# Altium schematic sheet IotMB_RevB.SchDoc
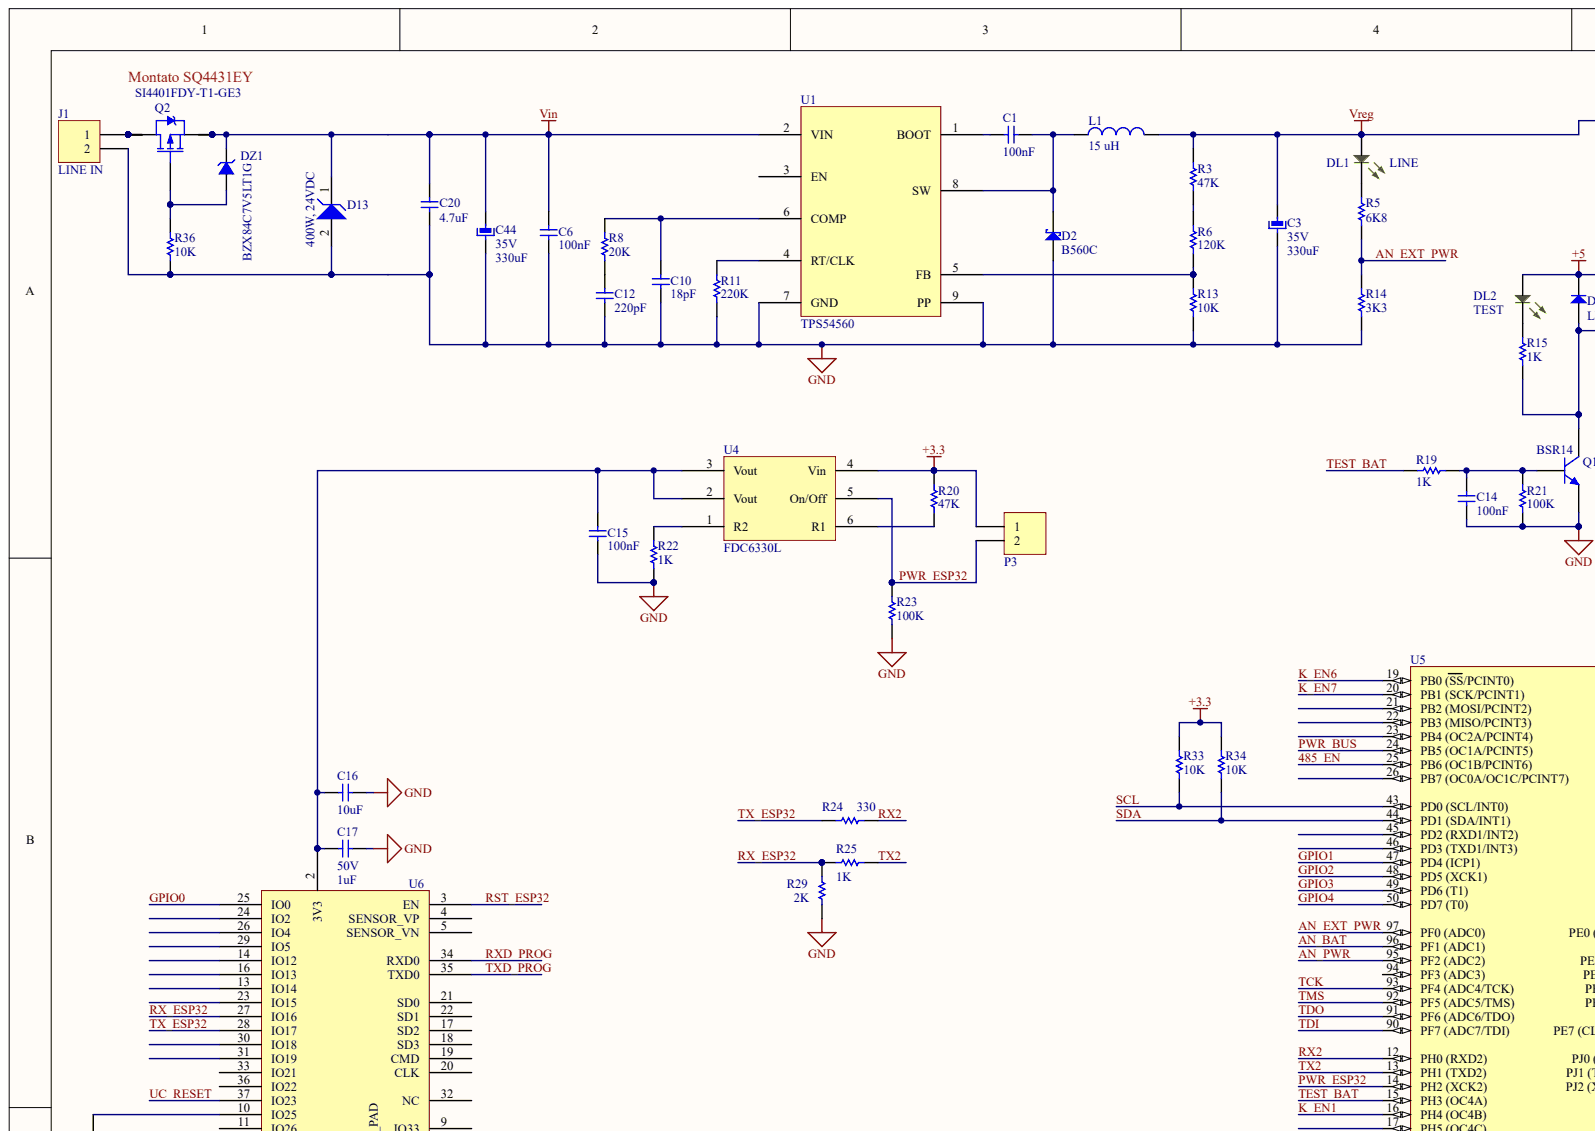
[diagram p0: IotMB_RevB.SchDoc, top left]
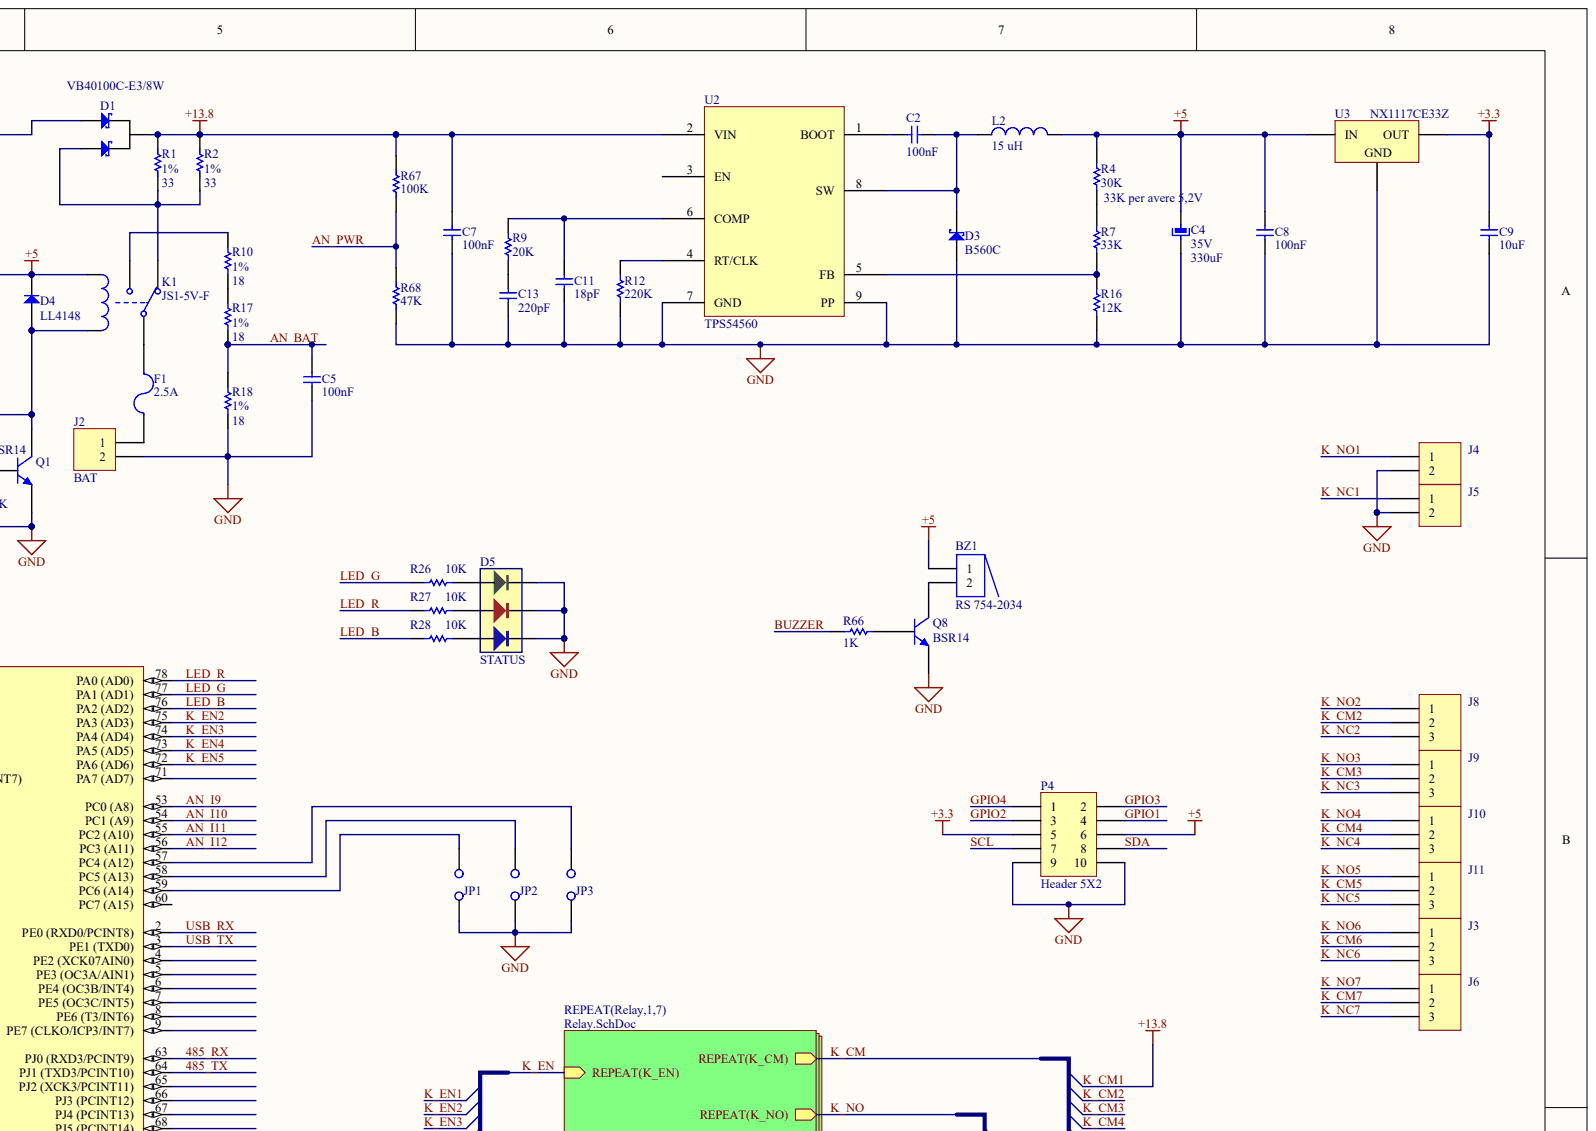
[diagram p1: IotMB_RevB.SchDoc, top right]
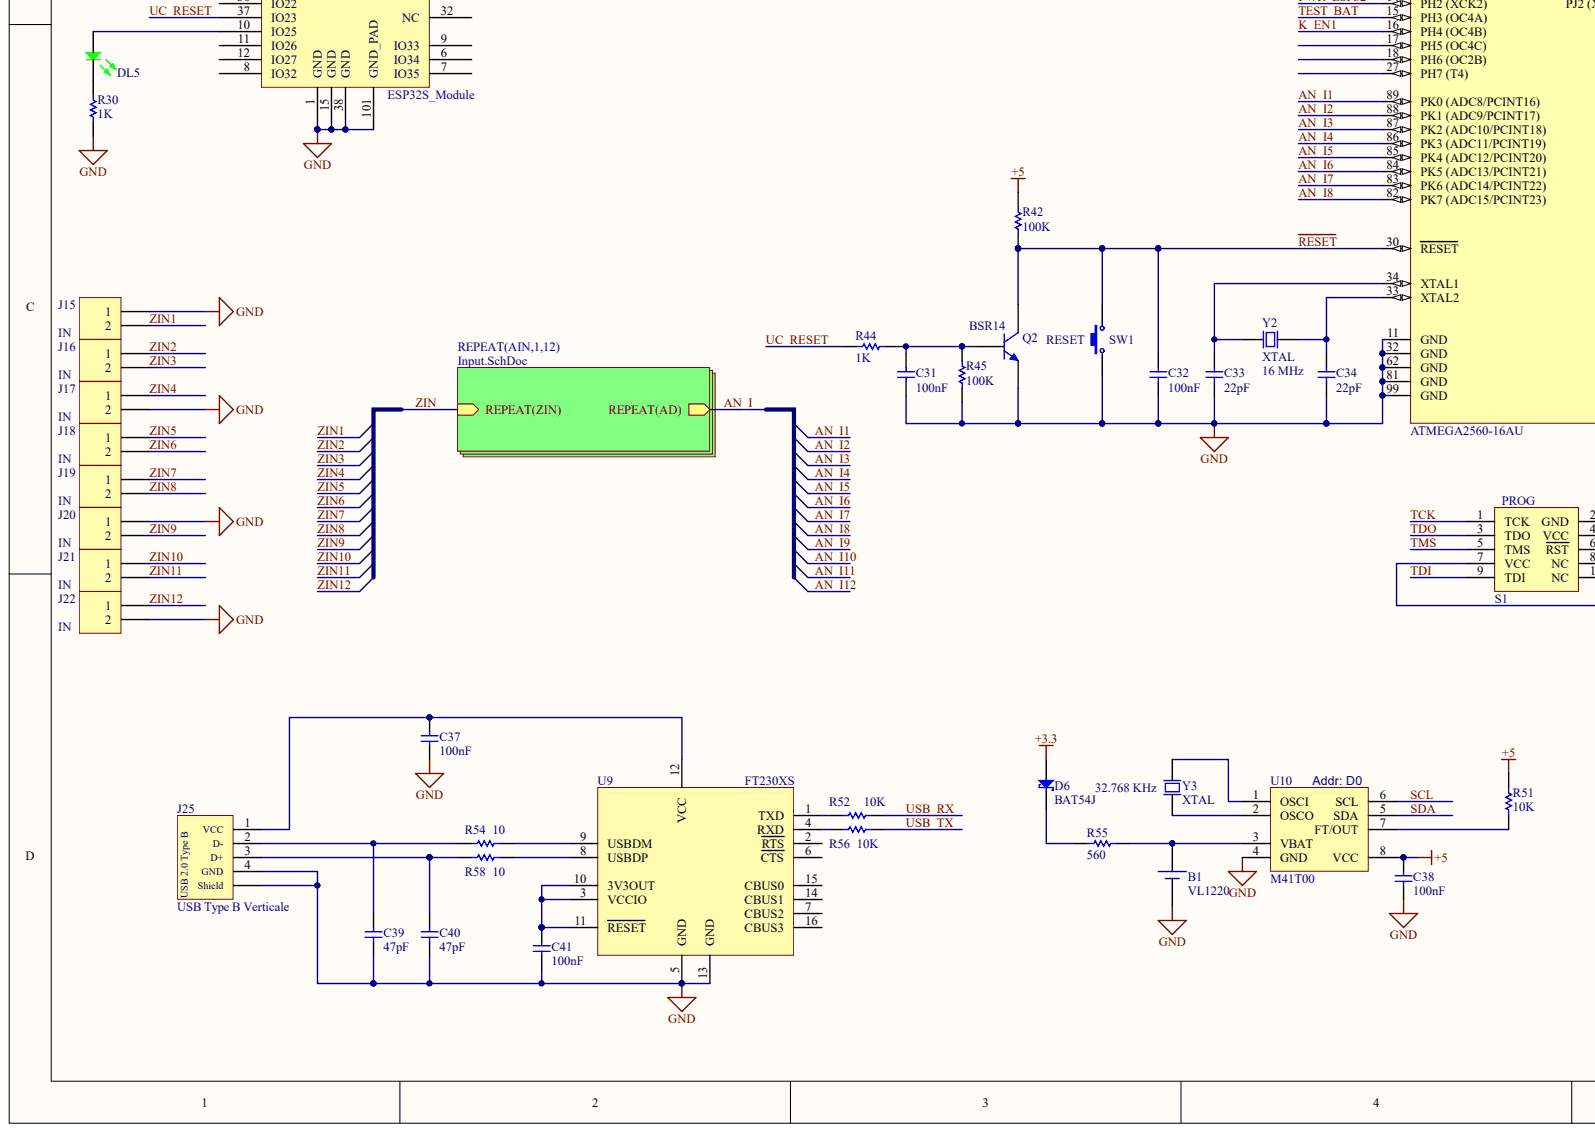
[diagram p2: IotMB_RevB.SchDoc, bottom left]
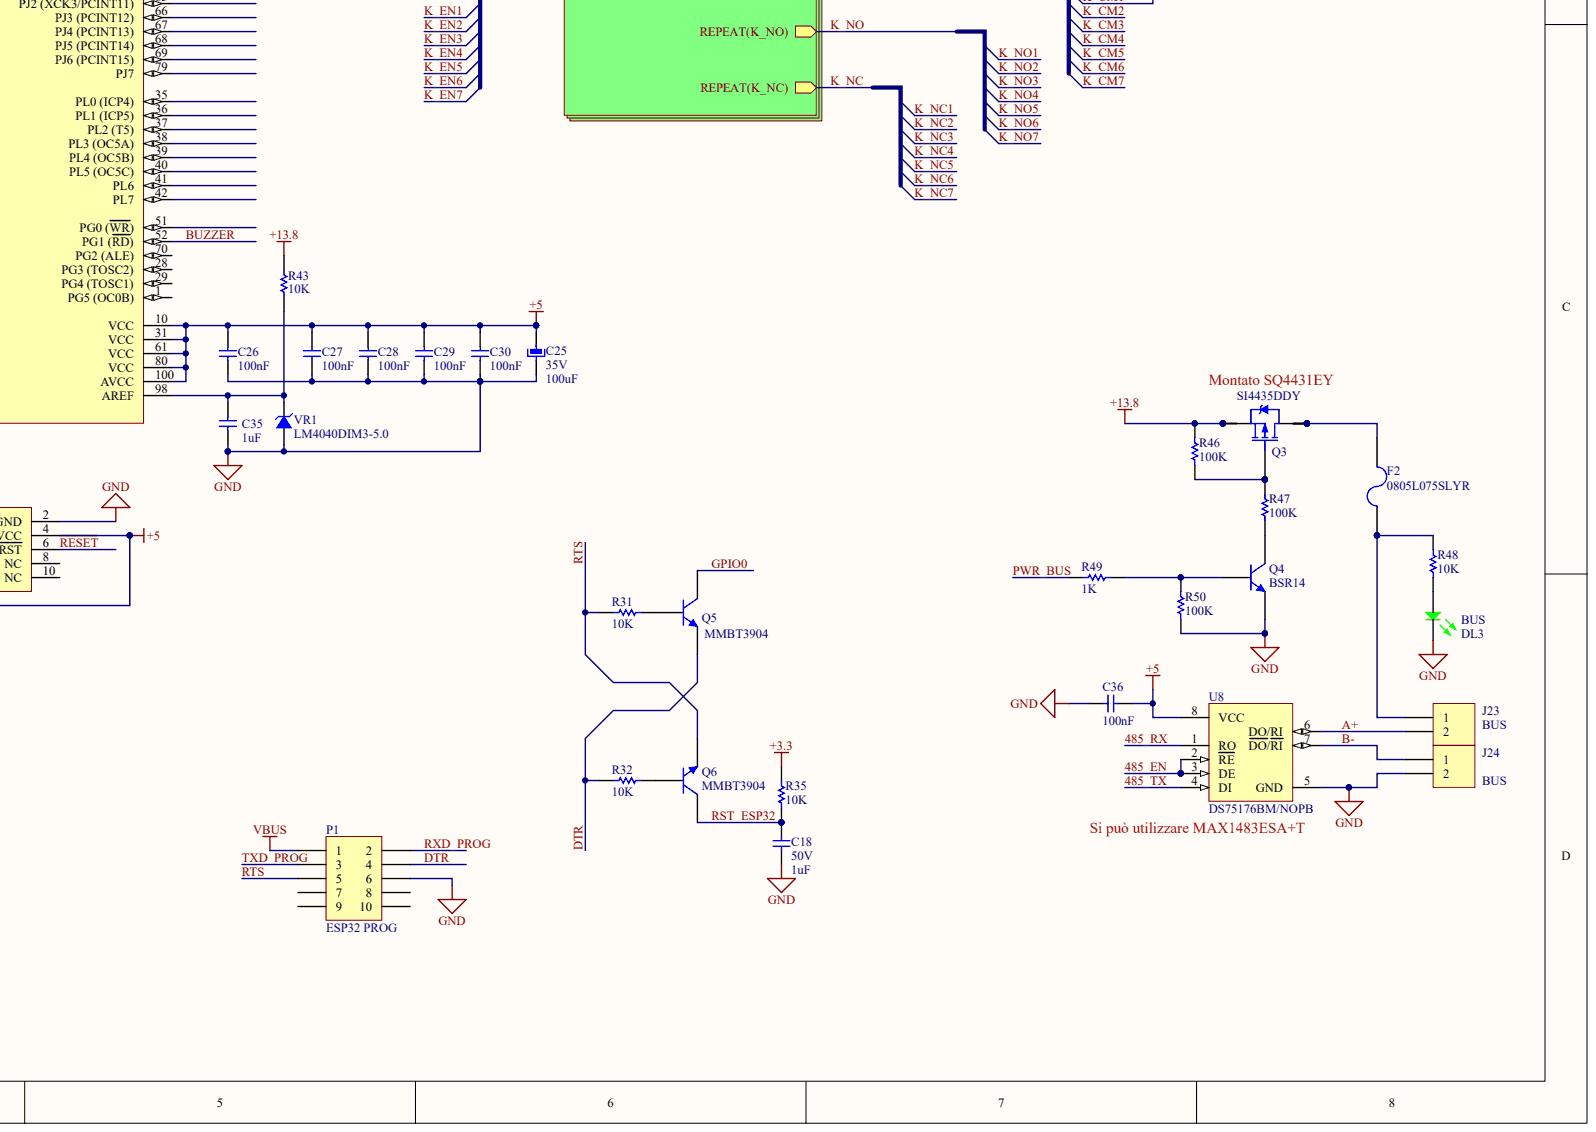
[diagram p3: IotMB_RevB.SchDoc, bottom right]

## Parts
B1  VL1220
BZ1  RS 754-2034
C1  value 100nF  (hidden comment: 50V)
C2  value 100nF  (hidden comment: 50V)
C3  35V  value 330uF
C4  35V  value 330uF
C5  value 100nF  (hidden comment: 50V)
C6  value 100nF  (hidden comment: 50V)
C7  value 100nF  (hidden comment: 50V)
C8  value 100nF  (hidden comment: 50V)
C9  value 10uF  (hidden comment: 25V)
C10  value 18pF  (hidden comment: 50V)
C11  value 18pF  (hidden comment: 50V)
C12  value 220pF  (hidden comment: 50V)
C13  value 220pF  (hidden comment: 50V)
C14  value 100nF  (hidden comment: 50V)
C15  value 100nF  (hidden comment: 50V)
C16  value 10uF  (hidden comment: 25V)
C17  50V  value 1uF
C18  50V  value 1uF
C20  value 4.7uF  (hidden comment: 50V)
C25  35V  value 100uF
C26  value 100nF  (hidden comment: 50V)
C27  value 100nF  (hidden comment: 50V)
C28  value 100nF  (hidden comment: 50V)
C29  value 100nF  (hidden comment: 50V)
C30  value 100nF  (hidden comment: 50V)
C31  value 100nF  (hidden comment: 50V)
C32  value 100nF  (hidden comment: 50V)
C33  value 22pF  (hidden comment: 100V)
C34  value 22pF  (hidden comment: 100V)
C35  value 1uF  (hidden comment: 50V)
C36  value 100nF  (hidden comment: 50V)
C37  value 100nF  (hidden comment: 50V)
C38  value 100nF  (hidden comment: 50V)
C39  value 47pF  (hidden comment: 50V)
C40  value 47pF  (hidden comment: 50V)
C41  value 100nF  (hidden comment: 50V)
C44  35V  value 330uF
D1  VB40100C-E3/8W
D2  B560C
D3  B560C
D4  LL4148
D5  STATUS
D6  BAT54J
D13  400W, 24VDC
DL1  LINE
DL2  TEST
DL3  BUS
DL5  Led verde 0805  (value not drawn)
DZ1  BZX84C7V5LT1G
F1  2.5A
F2  0805L075SLYR
J1  LINE IN
J2  BAT
J3  Morsetto 3 Poli a Vite  (value not drawn)
J4  Morsetto 2 Poli a Vite  (value not drawn)
J5  Morsetto 2 Poli a Vite  (value not drawn)
J6  Morsetto 3 Poli a Vite  (value not drawn)
J8  Morsetto 3 Poli a Vite  (value not drawn)
J9  Morsetto 3 Poli a Vite  (value not drawn)
J10  Morsetto 3 Poli a Vite  (value not drawn)
J11  Morsetto 3 Poli a Vite  (value not drawn)
J15  IN
J16  IN
J17  IN
J18  IN
J19  IN
J20  IN
J21  IN
J22  IN
J23  BUS
J24  BUS
J25  USB Type B Verticale  Interface Type: Type B  Sub-Family: USB 2.0
JP1  Jumper  (value not drawn)
JP2  Jumper  (value not drawn)
JP3  Jumper  (value not drawn)
K1  JS1-5V-F
L1  value 15 uH  (hidden comment: 8A)
L2  value 15 uH  (hidden comment: 8A)
P1  ESP32 PROG
P3  Header 2  (value not drawn)
P4  Header 5X2
Q1  BSR14
Q2  SI4401FDY-T1-GE3
Q2  BSR14
Q3  SI4435DDY
Q4  BSR14
Q5  MMBT3904
Q6  MMBT3904
Q8  BSR14
R1  1%  value 33
R2  1%  value 33
R3  value 47K  (hidden comment: 125mW 1%)
R4  value 30K  (hidden comment: 125mW 1%)
R5  value 6K8  (hidden comment: 125mW 1%)
R6  value 120K  (hidden comment: 125mW 1%)
R7  value 33K  (hidden comment: 125mW 1%)
R8  value 20K  (hidden comment: 125mW 1%)
R9  value 20K  (hidden comment: 125mW 1%)
R10  1%  value 18
R11  value 220K  (hidden comment: 125mW 1%)
R12  value 220K  (hidden comment: 125mW 1%)
R13  value 10K  (hidden comment: 125mW 1%)
R14  value 3K3  (hidden comment: 125mW 1%)
R15  value 1K  (hidden comment: 125mW 1%)
R16  value 12K  (hidden comment: 125mW 1%)
R17  1%  value 18
R18  1%  value 18
R19  value 1K  (hidden comment: 125mW 1%)
R20  value 47K  (hidden comment: 125mW 1%)
R21  value 100K  (hidden comment: 125mW 1%)
R22  value 1K  (hidden comment: 125mW 1%)
R23  value 100K  (hidden comment: 125mW 1%)
R24  value 330  (hidden comment: 125mW 1%)
R25  value 1K  (hidden comment: 125mW 1%)
R26  value 10K  (hidden comment: 125mW 1%)
R27  value 10K  (hidden comment: 125mW 1%)
R28  value 10K  (hidden comment: 125mW 1%)
R29  value 2K  (hidden comment: 125mW 1%)
R30  value 1K  (hidden comment: 125mW 1%)
R31  value 10K  (hidden comment: 125mW 1%)
R32  value 10K  (hidden comment: 125mW 1%)
R33  value 10K  (hidden comment: 125mW 1%)
R34  value 10K  (hidden comment: 125mW 1%)
R35  value 10K  (hidden comment: 125mW 1%)
R36  value 10K  (hidden comment: 125mW 1%)
R42  value 100K  (hidden comment: 125mW 1%)
R43  value 10K  (hidden comment: 125mW 1%)
R44  value 1K  (hidden comment: 125mW 1%)
R45  value 100K  (hidden comment: 125mW 1%)
R46  value 100K  (hidden comment: 125mW 1%)
R47  value 100K  (hidden comment: 125mW 1%)
R48  value 10K  (hidden comment: 125mW 1%)
R49  value 1K  (hidden comment: 125mW 1%)
R50  value 100K  (hidden comment: 125mW 1%)
R51  value 10K  (hidden comment: 125mW 1%)
R52  value 10K  (hidden comment: 125mW)
R54  value 10  (hidden comment: 125mW 1%)
R55  value 560  (hidden comment: 125mW 1%)
R56  value 10K  (hidden comment: 125mW)
R58  value 10  (hidden comment: 125mW 1%)
R66  value 1K  (hidden comment: 125mW 1%)
R67  value 100K  (hidden comment: 125mW 1%)
R68  value 47K  (hidden comment: 125mW 1%)
S1  PROG
SW1  RESET
U1  TPS54560
U2  TPS54560
U3  NX1117CE33Z
U4  FDC6330L
U5  ATMEGA2560-16AU
U6  ESP32S_Module
U8  DS75176BM/NOPB
U9  FT230XS
U10  M41T00
VR1  LM4040DIM3-5.0
Y2  XTAL  value 16 MHz
Y3  XTAL  value 32.768 KHz

## Nets
+3.3: C9.2 D6.1 P3.1 P4.5 R20.2 R33.2 R34.2 R35.2 U3.2 U4.4(Vin)
+5: BZ1.1 C4.1 C8.2 C25.1 C26.2 C27.2 C28.2 C29.2 C30.2 C36.1 C38.2 D4.2 DL2.1 K1.5 L2.2 P4.6 R4.2 R42.2 R51.2 S1.4(VCC) S1.7(VCC) U3.3 U5.10(VCC) U5.31(VCC) U5.61(VCC) U5.80(VCC) U5.100(AVCC) U8.8(VCC) U10.8(VCC)
GND: B1.2 C3.2 C4.2 C5.1 C6.1 C7.1 C8.1 C9.1 C10.1 C11.1 C12.1 C13.1 C14.1 C15.1 C16.1 C17.1 C18.2 C20.1 C25.2 C26.1 C27.1 C28.1 C29.1 C30.1 C31.1 C32.1 C33.1 C34.1 C35.2 C36.2 C37.1 C38.1 C39.1 C40.1 C41.1 C44.2 D2.1 D3.1 D5.1 D5.2 D5.3 D13.2 DL3.2 J1.2 J2.2 J4.2 J5.2 J15.1 J17.2 J20.1 J22.2 J24.2 J25.4(GND) J25.Shell(Shield) JP1.1 JP2.1 JP3.1 P1.6 P4.9 P4.10 Q1.3 Q2.3 Q4.3 Q8.3 R11.1 R12.1 R13.1 R14.1 R16.1 R18.1 R21.1 R22.1 R23.1 R29.1 R30.1 R36.1 R45.1 R50.1 R68.1 S1.2(GND) SW1.1 U1.7(GND) U1.9(PP) U2.7(GND) U2.9(PP) U3.1 U5.11(GND) U5.32(GND) U5.62(GND) U5.81(GND) U5.99(GND) U6.1(GND) U6.15(GND) U6.38(GND) U6.101(GND_PAD) U8.5(GND) U9.5(GND) U9.13(GND) U10.4(GND) VR1.1
VBUS: P1.1
VIN: C6.2 C20.2 C44.1 D13.1 DZ1.3 Q2.1 Q2.2 Q2.3 U1.2(VIN)
VREG: C3.1 D1.1 DL1.1 L1.2 R3.2
485_EN: U5.25(PB6_(OC1B/PCINT6)) U8.2(RE) U8.3(DE)
485_RX: U5.63(PJ0_(RXD3/PCINT9)) U8.1(RO)
485_TX: U5.64(PJ1_(TXD3/PCINT10)) U8.4(DI)
A+: J23.2 U8.6(DO/RI)
AN_BAT: C5.2 R17.1 R18.2 U5.96(PF1_(ADC1))
AN_EXT_PWR: R5.1 R14.2 U5.97(PF0_(ADC0))
AN_I1: U5.89(PK0_(ADC8/PCINT16))
AN_I2: U5.88(PK1_(ADC9/PCINT17))
AN_I3: U5.87(PK2_(ADC10/PCINT18))
AN_I4: U5.86(PK3_(ADC11/PCINT19))
AN_I5: U5.85(PK4_(ADC12/PCINT20))
AN_I6: U5.84(PK5_(ADC13/PCINT21))
AN_I7: U5.83(PK6_(ADC14/PCINT22))
AN_I8: U5.82(PK7_(ADC15/PCINT23))
AN_I9: U5.53(PC0_(A8))
AN_I10: U5.54(PC1_(A9))
AN_I11: U5.55(PC2_(A10))
AN_I12: U5.56(PC3_(A11))
AN_PWR: R67.1 R68.2 U5.95(PF2_(ADC2))
B-: J24.1 U8.7(DO/RI)
BUZZER: R66.2 U5.52(PG1_(RD))
DTR: P1.4 Q5.2 R32.1
GPIO0: Q5.3 U6.25(IO0)
GPIO1: P4.4 U5.47(PD4_(ICP1))
GPIO2: P4.3 U5.48(PD5_(XCK1))
GPIO3: P4.2 U5.49(PD6_(T1))
GPIO4: P4.1 U5.50(PD7_(T0))
K_CM1: C7.2 D1.3 Q3.1 Q3.2 Q3.3 R1.2 R2.2 R43.2 R46.2 R67.2 U2.2(VIN)
K_CM2: J8.2
K_CM3: J9.2
K_CM4: J10.2
K_CM5: J11.2
K_CM6: J3.2
K_CM7: J6.2
K_EN1: U5.16(PH4_(OC4B))
K_EN2: U5.75(PA3_(AD3))
K_EN3: U5.74(PA4_(AD4))
K_EN4: U5.73(PA5_(AD5))
K_EN5: U5.72(PA6_(AD6))
K_EN6: U5.19(PB0_(SS/PCINT0))
K_EN7: U5.20(PB1_(SCK/PCINT1))
K_NC1: J5.1
K_NC2: J8.3
K_NC3: J9.3
K_NC4: J10.3
K_NC5: J11.3
K_NC6: J3.3
K_NC7: J6.3
K_NO1: J4.1
K_NO2: J8.1
K_NO3: J9.1
K_NO4: J10.1
K_NO5: J11.1
K_NO6: J3.1
K_NO7: J6.1
LED_B: R28.2 U5.76(PA2_(AD2))
LED_G: R26.1 U5.77(PA1_(AD1))
LED_R: R27.2 U5.78(PA0_(AD0))
PWR_BUS: R49.1 U5.24(PB5_(OC1A/PCINT5))
PWR_ESP32: P3.2 R23.2 U4.5(On/Off) U5.14(PH2_(XCK2))
~{RESET}: C32.2 Q2.1 R42.1 S1.6(RST) SW1.2 U5.30(RESET)
RST_ESP32: C18.1 Q6.3 R35.1 U6.3(EN)
RTS: P1.5 Q6.2 R31.1
RX2: R24.2 U5.12(PH0_(RXD2))
RX_ESP32: R25.1 R29.2 U6.27(IO16)
RXD_PROG: P1.2 U6.34(RXD0)
SCL: P4.7 R33.1 U5.43(PD0_(SCL/INT0)) U10.6(SCL)
SDA: P4.8 R34.1 U5.44(PD1_(SDA/INT1)) U10.5(SDA)
TCK: S1.1(TCK) U5.93(PF4_(ADC4/TCK))
TDI: S1.9(TDI) U5.90(PF7_(ADC7/TDI))
TDO: S1.3(TDO) U5.91(PF6_(ADC6/TDO))
TEST_BAT: R19.1 U5.15(PH3_(OC4A))
TMS: S1.5(TMS) U5.92(PF5_(ADC5/TMS))
TX2: R25.2 U5.13(PH1_(TXD2))
TX_ESP32: R24.1 U6.28(IO17)
TXD_PROG: P1.3 U6.35(TXD0)
UC_RESET: R44.1 U6.37(IO23)
USB_RX: R52.2 U5.2(PE0_(RXD0/PCINT8))
USB_TX: R56.2 U5.3(PE1_(TXD0))
ZIN1: J15.2
ZIN2: J16.1
ZIN3: J16.2
ZIN4: J17.1
ZIN5: J18.1
ZIN6: J18.2
ZIN7: J19.1
ZIN8: J19.2
ZIN9: J20.2
ZIN10: J21.1
ZIN11: J21.2
ZIN12: J22.1
NetB1_1: B1.1 R55.2 U10.3(VBAT)
NetBZ1_2: BZ1.2 Q8.1
NetC1_1: C1.1 U1.1(BOOT)
NetC1_2: C1.2 D2.2 L1.1 U1.8(SW)
NetC2_1: C2.1 U2.1(BOOT)
NetC2_2: C2.2 D3.2 L2.1 U2.8(SW)
NetC10_2: C10.2 R8.2 U1.6(COMP)
NetC11_2: C11.2 R9.2 U2.6(COMP)
NetC12_2: C12.2 R8.1
NetC13_2: C13.2 R9.1
NetC14_2: C14.2 Q1.2 R19.2 R21.2
NetC15_2: C15.2 C16.2 C17.2 U4.2(Vout) U4.3(Vout) U6.2(3V3)
NetC31_2: C31.2 Q2.2 R44.2 R45.2
NetC33_2: C33.2 U5.34(XTAL1) Y2.1
NetC34_2: C34.2 U5.33(XTAL2) Y2.2
NetC35_1: C35.1 R43.1 U5.98(AREF) VR1.2
NetC37_2: C37.2 J25.1(VCC) U9.12(VCC)
NetC39_2: C39.2 J25.2(D-) R54.1
NetC40_2: C40.2 J25.3(D+) R58.1
NetC41_2: C41.2 U9.3(VCCIO) U9.10(3V3OUT) U9.11(RESET)
NetD1_2: D1.2 K1.4 R1.1 R2.1
NetD4_1: D4.1 K1.2 Q1.1 R15.2
NetD5_4: D5.4 R27.1
NetD5_5: D5.5 R26.2
NetD5_6: D5.6 R28.1
NetD6_2: D6.2 R55.1
NetDL1_2: DL1.2 R5.2
NetDL2_2: DL2.2 R15.1
NetDL3_1: DL3.1 R48.1
NetDL5_1: DL5.1 U6.10(IO25)
NetDL5_2: DL5.2 R30.2
NetDZ1_1: DZ1.1 Q2.4 R36.2
NetF1_1: F1.1 J2.1
NetF1_2: F1.2 K1.1
NetF2_1: F2.1 J23.1 R48.2
NetF2_2: F2.2 Q3.5 Q3.6 Q3.7 Q3.8 Q3.9
NetJ1_1: J1.1 Q2.5 Q2.6 Q2.7 Q2.8 Q2.9
NetJP1_2: JP1.2 U5.59(PC6_(A14))
NetJP2_2: JP2.2 U5.58(PC5_(A13))
NetJP3_2: JP3.2 U5.57(PC4_(A12))
NetK1_3: K1.3 R10.2
NetP1_7: P1.7
NetP1_8: P1.8
NetP1_9: P1.9
NetP1_10: P1.10
NetQ3_4: Q3.4 R46.1 R47.2
NetQ4_1: Q4.1 R47.1
NetQ4_2: Q4.2 R49.2 R50.2
NetQ5_1: Q5.1 R31.2
NetQ6_1: Q6.1 R32.2
NetQ8_2: Q8.2 R66.1
NetR3_1: R3.1 R6.2
NetR4_1: R4.1 R7.2
NetR6_1: R6.1 R13.2 U1.5(FB)
NetR7_1: R7.1 R16.2 U2.5(FB)
NetR10_1: R10.1 R17.2
NetR11_2: R11.2 U1.4(RT/CLK)
NetR12_2: R12.2 U2.4(RT/CLK)
NetR20_1: R20.1 U4.6(R1)
NetR22_2: R22.2 U4.1(R2)
NetR51_1: R51.1 U10.7(FT/OUT)
NetR52_1: R52.1 U9.1(TXD)
NetR54_2: R54.2 U9.9(USBDM)
NetR56_1: R56.1 U9.4(RXD)
NetR58_2: R58.2 U9.8(USBDP)
NetS1_8: S1.8(NC)
NetS1_10: S1.10(NC)
NetU1_3: U1.3(EN)
NetU2_3: U2.3(EN)
NetU5_1: U5.1(PG5_(OC0B))
NetU5_4: U5.4(PE2_(XCK07AIN0))
NetU5_5: U5.5(PE3_(OC3A/AIN1))
NetU5_6: U5.6(PE4_(OC3B/INT4))
NetU5_7: U5.7(PE5_(OC3C/INT5))
NetU5_8: U5.8(PE6_(T3/INT6))
NetU5_9: U5.9(PE7_(CLKO/ICP3/INT7))
NetU5_17: U5.17(PH5_(OC4C))
NetU5_18: U5.18(PH6_(OC2B))
NetU5_21: U5.21(PB2_(MOSI/PCINT2))
NetU5_22: U5.22(PB3_(MISO/PCINT3))
NetU5_23: U5.23(PB4_(OC2A/PCINT4))
NetU5_26: U5.26(PB7_(OC0A/OC1C/PCINT7))
NetU5_27: U5.27(PH7_(T4))
NetU5_28: U5.28(PG3_(TOSC2))
NetU5_29: U5.29(PG4_(TOSC1))
NetU5_35: U5.35(PL0_(ICP4))
NetU5_36: U5.36(PL1_(ICP5))
NetU5_37: U5.37(PL2_(T5))
NetU5_38: U5.38(PL3_(OC5A))
NetU5_39: U5.39(PL4_(OC5B))
NetU5_40: U5.40(PL5_(OC5C))
NetU5_41: U5.41(PL6)
NetU5_42: U5.42(PL7)
NetU5_45: U5.45(PD2_(RXD1/INT2))
NetU5_46: U5.46(PD3_(TXD1/INT3))
NetU5_51: U5.51(PG0_(WR))
NetU5_60: U5.60(PC7_(A15))
NetU5_65: U5.65(PJ2_(XCK3/PCINT11))
NetU5_66: U5.66(PJ3_(PCINT12))
NetU5_67: U5.67(PJ4_(PCINT13))
NetU5_68: U5.68(PJ5_(PCINT14))
NetU5_69: U5.69(PJ6_(PCINT15))
NetU5_70: U5.70(PG2_(ALE))
NetU5_71: U5.71(PA7_(AD7))
NetU5_79: U5.79(PJ7)
NetU5_94: U5.94(PF3_(ADC3))
NetU6_4: U6.4(SENSOR_VP)
NetU6_5: U6.5(SENSOR_VN)
NetU6_6: U6.6(IO34)
NetU6_7: U6.7(IO35)
NetU6_8: U6.8(IO32)
NetU6_9: U6.9(IO33)
NetU6_11: U6.11(IO26)
NetU6_12: U6.12(IO27)
NetU6_13: U6.13(IO14)
NetU6_14: U6.14(IO12)
NetU6_16: U6.16(IO13)
NetU6_17: U6.17(SD2)
NetU6_18: U6.18(SD3)
NetU6_19: U6.19(CMD)
NetU6_20: U6.20(CLK)
NetU6_21: U6.21(SD0)
NetU6_22: U6.22(SD1)
NetU6_23: U6.23(IO15)
NetU6_24: U6.24(IO2)
NetU6_26: U6.26(IO4)
NetU6_29: U6.29(IO5)
NetU6_30: U6.30(IO18)
NetU6_31: U6.31(IO19)
NetU6_32: U6.32(NC)
NetU6_33: U6.33(IO21)
NetU6_36: U6.36(IO22)
NetU9_2: U9.2(RTS)
NetU9_6: U9.6(CTS)
NetU9_7: U9.7(CBUS2)
NetU9_14: U9.14(CBUS1)
NetU9_15: U9.15(CBUS0)
NetU9_16: U9.16(CBUS3)
NetU10_1: U10.1(OSCI) Y3.1
NetU10_2: U10.2(OSCO) Y3.2
N00066:
N00067:
N00068:
N00069:
N00070:
N00071:

## Library data of the parts
B1 = (no symbol name): Codice RS: 407-861; Codice GMV: *; Codice Mouser: *; Codice Wurth: *; Codice Farnell: *
C1 C2 C5-C8 C14 C15 C26-C32 C36-C38 C41 = (no symbol name): Codice GMV: CE000055; Codice RS: 723-5042P; Codice Wurth: *; Codice Mouser: *
  C5-C7 C14 C15 C26-C32 C36-C38 C41: Codice Farnell: *
C3 C4 C44 = (no symbol name): Codice RS: 839-2073; Codice Wurth: 865080557015; Codice GMV: *; Codice Mouser: 710-865080557015; Codice Farnell: *
C12 C13 = (no symbol name): Codice GMV: CE000050; Codice RS: *; Codice Mouser: *; Codice Wurth: *
C17 = (no symbol name): Codice GMV: CE000057; Codice RS: 723-6350P; Value: 1uF; Codice Mouser: 595-MAX3221IPW; Codice Wurth: *; Codice Farnell: *
C18 C35 = (no symbol name): Codice GMV: CE000057; Codice RS: 723-6350P; Codice Mouser: 595-MAX3221IPW; Codice Wurth: *; Codice Farnell: *
C33 C34 = (no symbol name): Codice GMV: CE000047; Codice RS: *; Codice Mouser: *; Codice Wurth: *; Codice Farnell: *
C39 C40 = (no symbol name): Codice GMV: CE000048; Codice RS: *; Codice Mouser: *; Codice Wurth: *; Codice Farnell: *
D1 = (no symbol name): Codice GMV: CE000343; Codice RS: 818-4392; Codice Farnell: *; Codice Mouser: *; Codice Wurth: *
D2 D3 = (no symbol name): Codice RS: 751-3301 /922-8345; Codice GMV: *; Codice Mouser: 621-B560C-F; Codice Wurth: *; Codice Farnell: *
D4 = (no symbol name): Codice GMV: CE000094; Codice RS: 438-2788; Codice Farnell: *; Codice Mouser: *; Codice Wurth: *
D6 = (no symbol name): Codice GMV: CE000100; Codice RS: 780-5363; Codice Mouser: 771-BAT54J115; Codice Farnell: *; Codice Wurth: *
D13 = (no symbol name): Part Number: 824500241; (Forward) Peak Pulse Current: 10.3A; Polarity: Unidirectional; (Reverse) Breakdown Voltage: 28.1V; DC Operating Voltage: 24V; Clamping Voltage: 38.9V; ComponentLink1Description: Manufacturer; ComponentLink1URL: http://www.we-online.de; ComponentLink2Description: Datasheet; ComponentLink2URL: https://katalog.we-online.com/pbs/datasheet/824500241.pdf; Published Date: March 2019; Size: DO-214AC; Operating Temperature: -65 to +150degC; Mounting Technology: SMT; Packaging: Tape and Reel; Steady State Power Dissipation: 400W; Publisher: Wurth Elektronik
DL3 DL5 = (no symbol name): Codice GMV: CE000340; Codice RS: 815-4221; Codice Wurth: 150080GS75000; Codice Farnell: *; Codice Mouser: 710-150080GS75000
J1 J2 J4 J5 J15-J24 = (no symbol name): Part Number: 691216710002; Pitch: 5 mm; Pin Number: 2; ComponentLink1Description: Manufacturer; ComponentLink1URL: http://www.we-online.de; ComponentLink2Description: Datasheet; ComponentLink2URL: http://katalog.we-online.de/em/datasheet/6912167100XX.pdf; Published Date: March 2017; Publisher: Wurth Elektronik
J3 J6 J8-J11 = (no symbol name): Part Number: 691216710003; Pitch: 5 mm; Pin Number: 3; ComponentLink1Description: Manufacturer; ComponentLink1URL: http://www.we-online.de; ComponentLink2Description: Datasheet; ComponentLink2URL: http://katalog.we-online.de/em/datasheet/6912167100XX.pdf; Published Date: March 2017; Publisher: Wurth Elektronik
J25 = (no symbol name): Part Number: 61400413321; Gender: Receptacle; ComponentLink1Description: Manufacturer; ComponentLink1URL: http://www.we-online.de; ComponentLink2Description: Datasheet; ComponentLink2URL: http://katalog.we-online.de/em/datasheet/61400413321.pdf; Published Date: April 2017; Type: Vertical; Construction: THT; Publisher: Wurth Elektronik
K1 = (no symbol name): Codice RS: 815-5114; Codice Farnell: *; Codice GMV: *; Codice Mouser: *; Codice Wurth: *
L1 L2 = (no symbol name): Codice RS: 744-4854; Codice Wurth: 7447709150; Codice GMV: *; Codice Mouser: 710-7447709150; Codice Farnell: *
P1 P4 = (no symbol name): Codice Wurth: 61301021121; Codice RS: 763-6754; Codice Mouser: 710-61301021121; Codice Farnell: *
P3 = (no symbol name): Codice Wurth: 61300211121; Codice RS: 828-1581; Codice GMV: *; Codice Farnell: *; Codice Mouser: 710-61300211121
Q1 Q2 Q4 Q8 = (no symbol name): Codice GMV: CE000110; Codice RS: 671-1129; Codice Mouser: 512-BSR14; Codice Wurth: *; Codice Farnell: *
Q5 Q6 = (no symbol name): Codice RS: 739-0303; Codice Farnell: *; Codice GMV: *; Codice Mouser: *; Codice Wurth: *
R4 R7 = (no symbol name): Codice GMV: CE000021; Codice RS: *; Codice Wurth: *; Codice Mouser: *; Codice Farnell: *
R5 = (no symbol name): Codice GMV: CE000013; Codice RS: *; Codice Wurth: *; Codice Mouser: *; Codice Farnell: *
R8 R9 = (no symbol name): Codice GMV: CE000019; Codice RS: *; Codice Wurth: *; Codice Mouser: *; Codice Farnell: *
R11 R12 = (no symbol name): Codice GMV: CE000028; Codice RS: *; Codice Wurth: *; Codice Mouser: *; Codice Farnell: *
R15 R19 R22 R26-R28 R30 R44 R48 R49 R66 = (no symbol name): Codice RS: 698-0075; Codice GMV: CE000006; Codice Wurth: *; Codice Mouser: *; Codice Farnell: *
R20 = (no symbol name): Codice GMV: CE000023; Codice RS: *; Codice Wurth: *; Codice Mouser: *; Codice Farnell: *
R21 R23 R42 R45-R47 R50 = (no symbol name): Codice RS: 689-0233; Codice GMV: CE000026; Codice Wurth: *; Codice Mouser: *; Codice Farnell: *
R31-R36 R43 R51 = (no symbol name): Codice RS: 698-0154; Codice GMV: CE000015; Codice Wurth: *; Codice Mouser: *; Codice Farnell: *
R54 R58 = (no symbol name): Codice GMV: CE000002; Codice RS: *; Codice Wurth: *; Codice Mouser: *; Codice Farnell: *
SW1 = (no symbol name): Codice Wurth: 430186050716; Codice GMV: CE000335; Codice RS: 785-6273; Codice Farnell: *; Codice Mouser: *
U4 = (no symbol name): Codice RS: 761-3372P; Codice GMV: CE000080; Codice Wurth: *; Codice Mouser: 512-FDC6330L; Codice Farnell: *
Y3 = (no symbol name): Codice RS: 672-7631; Codice Farnell: *; Codice GMV: *; Codice Mouser: *; Codice Wurth: *
BZ1 C9-C11 C16 C20 C25 D5 DL1 DL2 DZ1 F1 F2 JP1-JP3 Q2 Q3 R1-R3 R6 R10 R13 R14 R16-R18 R24 R25 R29 R52 R55 R56 R67 R68 S1 U1-U3 U5 U6 U8-U10 VR1 Y2 = (no symbol name)
  C9 C16 C20 F2 U8: Codice GMV: *; Codice RS: *; Codice Mouser: *; Codice Wurth: *; Codice Farnell: *
  C10 C11: Codice GMV: *; Codice RS: *; Codice Mouser: *; Codice Wurth: *
  D5 Q3 U1 U2 U10: Codice Farnell: *; Codice GMV: *; Codice Mouser: *; Codice RS: *; Codice Wurth: *
  DL1 DL2: Codice Farnell: *; Codice Mouser: *; Codice GMV: *; Codice RS: *; Codice Wurth: *
  DZ1: Codice GMV: *; Codice RS: *; Codice Mouser: 863-BZX84C7V5LT1G; Codice Wurth: *; Codice Farnell: *
  F1: Codice Farnell: *; Codice GMV: *; codice Mouser: *; Codice RS: *; Codice Wurth: *
  Q2: Codice Farnell: *; Codice GMV: *; Codice Mouser: 78-SI4401FDY-T1-GE3; Codice RS: *; Codice Wurth: *
  R1 R2 R10 R17 R18: Codice GMV: *; Codice RS: *; Codice Wurth: *; Codice Mouser: *; Codice Farnell: *
  R3: Codice RS: *; Codice Mouser: *; Codice Farnell: *
  R6: Codice RS: *; Codice Wurth: *; Codice Mouser: *; Codice Farnell: *
  R13 R14 R16 R24 R25 R29 R55 R67 R68: Codice RS: *; Codice Wurth: *; Codice Mouser: *; Codice GMV: *; Codice Farnell: *
  U3: Codice GMV: *; Codice Farnell: *; Codice Mouser: *; Codice RS: *; Codice Wurth: *
  U6: Datasheet: https://espressif.com/sites/default/files/documentation/esp_wroom_32_datasheet_en.pdf
